# Altium schematic sheet DEVICE.SchDoc
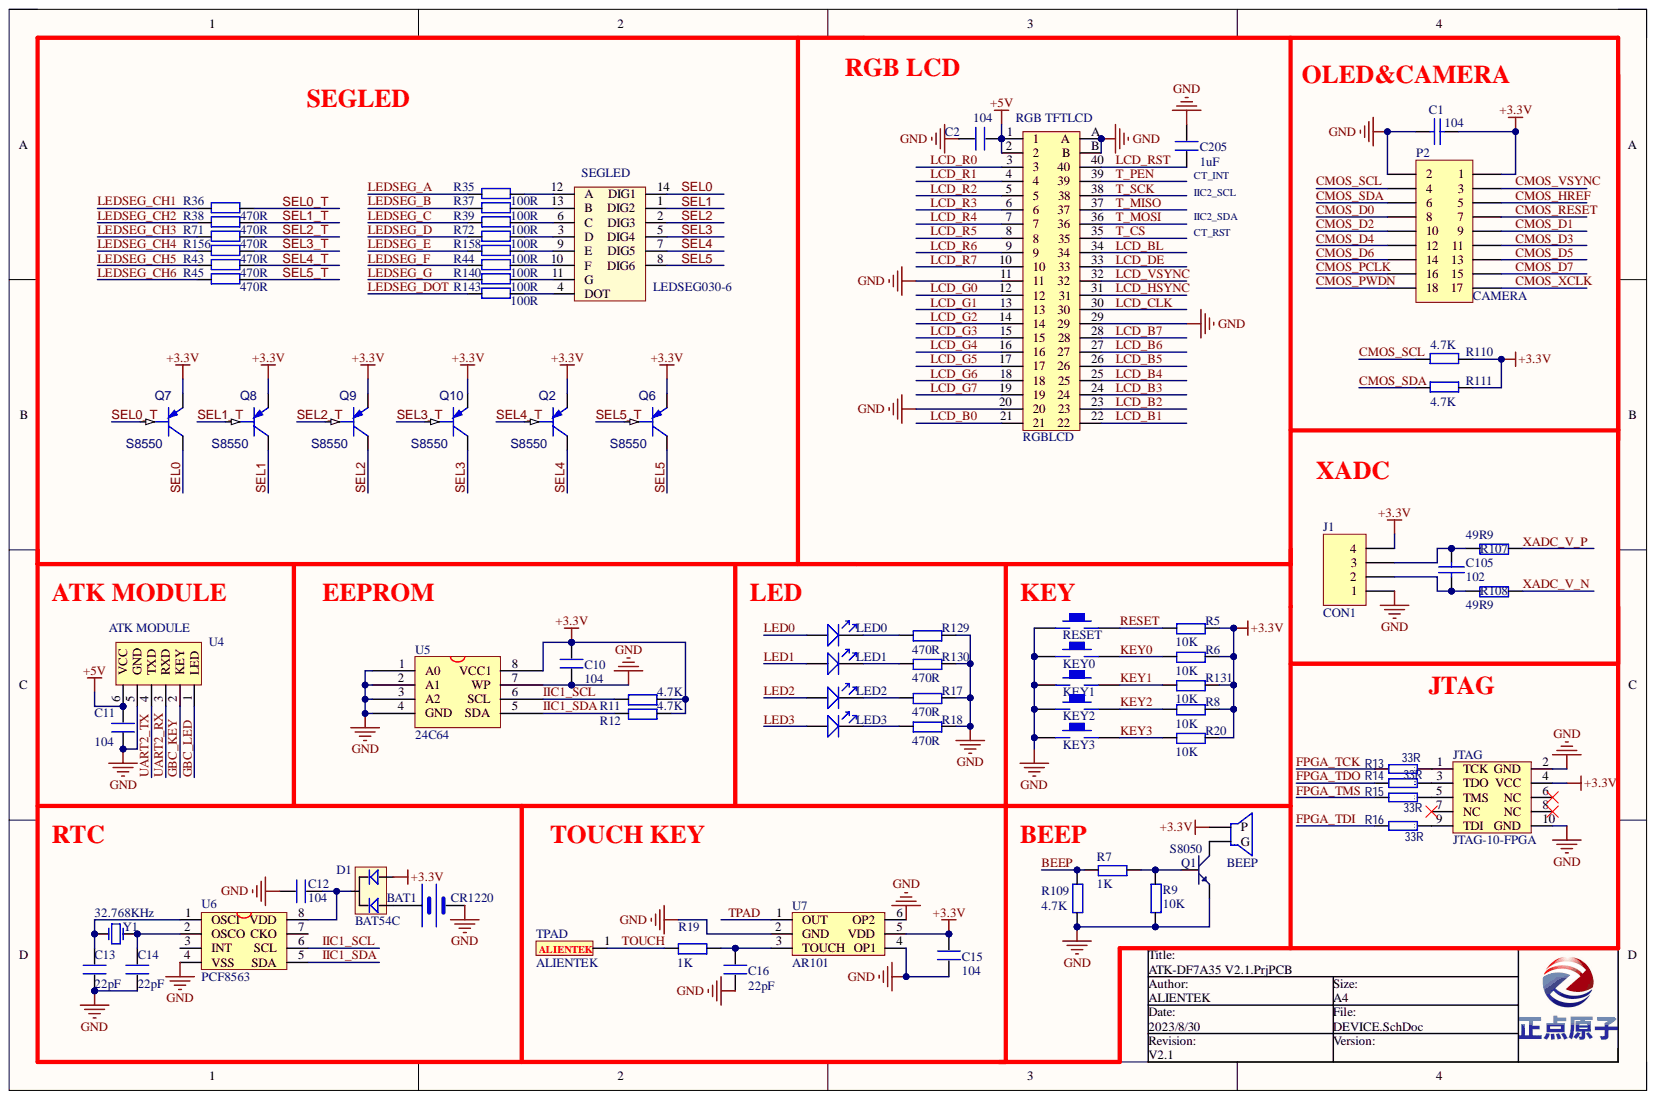
[diagram p0: DEVICE.SchDoc]

## Parts
BAT1  CR1220  [BATM]
BEEP  (no value)  [BELL-5]
C1  104  [0603P]
C2  104  [0603P]
C10  104  [0603P]
C11  104  [0603P]
C12  104  [0603P]
C13  22pF  [0603P]
C14  22pF  [0603P]
C15  104  [0603P]
C16  22pF  [0603P]
C105  102  [0603P]
C205  1uF  [0603P]
D1  BAT54C  [SOT23]
J1  CON1  [HDR1X4]
JTAG  JTAG-10-FPGA  [HDR-IDC-SMD_10PIN-V-ROW2-COL5-PITCH2.00]
KEY0  KEY_M  [KEY_SOP324225]  (value not drawn)
KEY1  KEY_M  [KEY_SOP324225]  (value not drawn)
KEY2  KEY_M  [KEY_SOP324225]  (value not drawn)
KEY3  KEY_M  [KEY_SOP324225]  (value not drawn)
LED0  PS_LED0  [SMDLED-0805]  (value not drawn)
LED1  PS_LED1  [SMDLED-0805]  (value not drawn)
LED2  PS_LED0  [SMDLED-0805]  (value not drawn)
LED3  PS_LED1  [SMDLED-0805]  (value not drawn)
P2  CAMERA  [HDR2X9]
Q1  S8050  [SOT-23R]
Q2  S8550  [SOT23]
Q6  S8550  [SOT23]
Q7  S8550  [SOT23]
Q8  S8550  [SOT23]
Q9  S8550  [SOT23]
Q10  S8550  [SOT23]
R5  10K  [0603P]
R6  10K  [0603P]
R7  1K  [0603P]
R8  10K  [0603P]
R9  10K  [0603P]
R11  4.7K  [0603P]
R12  4.7K  [0603P]
R13  33R  [0603P]
R14  33R  [0603P]
R15  33R  [0603P]
R16  33R  [0603P]
R17  470R  [0603P]
R18  470R  [0603P]
R19  1K  [0603P]
R20  10K  [0603P]
R35  100R  [0603P]
R36  470R  [0603P]
R37  100R  [0603P]
R38  470R  [0603P]
R39  100R  [0603P]
R43  470R  [0603P]
R44  100R  [0603P]
R45  470R  [0603P]
R71  470R  [0603P]
R72  100R  [0603P]
R107  49R9  [0603P]
R108  49R9  [0603P]
R109  4.7K  [0603P]
R110  4.7K  [0603P]
R111  4.7K  [0603P]
R129  470R  [0603P]
R130  470R  [0603P]
R131  10K  [0603P]
R140  100R  [0603P]
R143  100R  [0603P]
R156  470R  [0603P]
R158  100R  [0603P]
RESET  KEY_M  [KEY_SOP324225]  (value not drawn)
RGB_TFTLCD  RGBLCD  [FPC-TOP40]
SEGLED  LEDSEG030-6  [leddisplay-0.3]
TPAD  ALIENTEK  [ALIENTEK_PAD1]
U4  ATK MODULE  [HEAD6]
U5  24C64  [SOP-8]
U6  PCF8563  [SOP-8]
U7  AR101  [SOT-23-6]
Y1  32.768KHz  [XTAL32]

## Nets
+3.3V: BEEP.1 C1.2 C10.2 C15.1 D1.2 J1.4 JTAG.4(VCC) P2.1 Q2.2 Q6.2 Q7.2 Q8.2 Q9.2 Q10.2 R5.2 R6.2 R8.2 R11.2 R12.2 R20.2 R110.1 R111.1 R131.2 U5.8(VCC1) U7.5(VDD)
+5V: C2.2 C11.1 RGB_TFTLCD.1 RGB_TFTLCD.2 U4.6(VCC)
GND: BAT1.2 C1.1 C2.1 C10.1 C11.2 C12.2 C13.2 C14.2 C15.2 C16.1 C205.2 J1.1 JTAG.2(GND) JTAG.10(GND) KEY0.1 KEY1.1 KEY2.1 KEY3.1 P2.2 Q1.1 R9.1 R17.2 R18.2 R109.1 R129.2 R130.2 RESET.1 RGB_TFTLCD.11 RGB_TFTLCD.20 RGB_TFTLCD.29 RGB_TFTLCD.A RGB_TFTLCD.B U4.5(GND) U5.1(A0) U5.2(A1) U5.3(A2) U5.4(GND) U5.7(WP) U6.4(VSS) U7.2(GND) U7.4(OP1) U7.6(OP2)
BEEP: R7.1 R109.2
CMOS_D0: P2.8
CMOS_D1: P2.9
CMOS_D2: P2.10
CMOS_D3: P2.11
CMOS_D4: P2.12
CMOS_D5: P2.13
CMOS_D6: P2.14
CMOS_D7: P2.15
CMOS_HREF: P2.5
CMOS_PCLK: P2.16
CMOS_PWDN: P2.18
CMOS_RESET: P2.7
CMOS_SCL: P2.4 R110.2
CMOS_SDA: P2.6 R111.2
CMOS_VSYNC: P2.3
CMOS_XCLK: P2.17
FPGA_TCK: R13.2
FPGA_TDI: R16.2
FPGA_TDO: R14.2
FPGA_TMS: R15.2
GBC_KEY: U4.2(KEY)
GBC_LED: U4.1(LED)
IIC1_SCL: R11.1 U5.6(SCL) U6.6(SCL)
IIC1_SDA: R12.1 U5.5(SDA) U6.5(SDA)
KEY0: KEY0.2 R6.1
KEY1: KEY1.2 R131.1
KEY2: KEY2.2 R8.1
KEY3: KEY3.2 R20.1
LCD_B0: RGB_TFTLCD.21
LCD_B1: RGB_TFTLCD.22
LCD_B2: RGB_TFTLCD.23
LCD_B3: RGB_TFTLCD.24
LCD_B4: RGB_TFTLCD.25
LCD_B5: RGB_TFTLCD.26
LCD_B6: RGB_TFTLCD.27
LCD_B7: RGB_TFTLCD.28
LCD_BL: RGB_TFTLCD.34
LCD_CLK: RGB_TFTLCD.30
LCD_DE: RGB_TFTLCD.33
LCD_G0: RGB_TFTLCD.12
LCD_G1: RGB_TFTLCD.13
LCD_G2: RGB_TFTLCD.14
LCD_G3: RGB_TFTLCD.15
LCD_G4: RGB_TFTLCD.16
LCD_G5: RGB_TFTLCD.17
LCD_G6: RGB_TFTLCD.18
LCD_G7: RGB_TFTLCD.19
LCD_HSYNC: RGB_TFTLCD.31
LCD_R0: RGB_TFTLCD.3
LCD_R1: RGB_TFTLCD.4
LCD_R2: RGB_TFTLCD.5
LCD_R3: RGB_TFTLCD.6
LCD_R4: RGB_TFTLCD.7
LCD_R5: RGB_TFTLCD.8
LCD_R6: RGB_TFTLCD.9
LCD_R7: RGB_TFTLCD.10
LCD_RST: C205.1 RGB_TFTLCD.40
LCD_VSYNC: RGB_TFTLCD.32
LED0: LED0.1
LED1: LED1.1
LED2: LED2.1
LED3: LED3.1
LEDSEG_A: R35.2
LEDSEG_B: R37.2
LEDSEG_C: R39.2
LEDSEG_CH1: R36.2
LEDSEG_CH2: R38.2
LEDSEG_CH3: R71.2
LEDSEG_CH4: R156.2
LEDSEG_CH5: R43.2
LEDSEG_CH6: R45.2
LEDSEG_D: R72.2
LEDSEG_DOT: R143.2
LEDSEG_E: R158.2
LEDSEG_F: R44.2
LEDSEG_G: R140.2
RESET: R5.1 RESET.2
SEL0: Q7.3 SEGLED.14(DIG1)
SEL0_T: Q7.1 R36.1
SEL1: Q8.3 SEGLED.1(DIG2)
SEL1_T: Q8.1 R38.1
SEL2: Q9.3 SEGLED.2(DIG3)
SEL2_T: Q9.1 R71.1
SEL3: Q10.3 SEGLED.5(DIG4)
SEL3_T: Q10.1 R156.1
SEL4: Q2.3 SEGLED.7(DIG5)
SEL4_T: Q2.1 R43.1
SEL5: Q6.3 SEGLED.8(DIG6)
SEL5_T: Q6.1 R45.1
T_CS: RGB_TFTLCD.35
T_MISO: RGB_TFTLCD.37
T_MOSI: RGB_TFTLCD.36
T_PEN: RGB_TFTLCD.39
T_SCK: RGB_TFTLCD.38
TOUCH: R19.1 TPAD.1
TPAD: U7.1(OUT)
UART2_RX: U4.3(RXD)
UART2_TX: U4.4(TXD)
XADC_V_N: R108.2
XADC_V_P: R107.2
NetBAT1_1: BAT1.1 D1.1
NetBEEP_2: BEEP.2 Q1.3
NetC12_1: C12.1 D1.3 U6.8(VDD)
NetC13_1: C13.1 U6.1(OSCI) Y1.2
NetC14_1: C14.1 U6.2(OSCO) Y1.1
NetC16_2: C16.2 R19.2 U7.3(TOUCH)
NetC105_1: C105.1 J1.2 R108.1
NetC105_2: C105.2 J1.3 R107.1
NetJTAG_1: JTAG.1(TCK) R13.1
NetJTAG_3: JTAG.3(TDO) R14.1
NetJTAG_5: JTAG.5(TMS) R15.1
NetJTAG_9: JTAG.9(TDI) R16.1
NetLED0_2: LED0.2 R129.1
NetLED1_2: LED1.2 R130.1
NetLED2_2: LED2.2 R17.1
NetLED3_2: LED3.2 R18.1
NetQ1_2: Q1.2 R7.2 R9.2
NetR35_1: R35.1 SEGLED.12(A)
NetR37_1: R37.1 SEGLED.13(B)
NetR39_1: R39.1 SEGLED.6(C)
NetR44_1: R44.1 SEGLED.10(F)
NetR72_1: R72.1 SEGLED.3(D)
NetR140_1: R140.1 SEGLED.11(G)
NetR143_1: R143.1 SEGLED.4(DOT)
NetR158_1: R158.1 SEGLED.9(E)

Not connected: JTAG 6(NC) 7(NC) 8(NC); U6 3(INT) 7(CKO)

## Library data of the parts
BAT1 = BAT: Component Kind: Standard; Library Name: ALIENTEK_COMPONENT.IntLib
BEEP = BEEP: Component Kind: Standard; Library Name: ALIENTEK_COMPONENT.IntLib
C1 C105 = CAP_104_0.1uf: Component Kind: Standard; Library Name: ZYNQ.SCHLIB
C2 C10-C16 C205 = C: Component Kind: Standard
  C2 C205: Library Name: ALIENTEK_COMPONENT.IntLib
  C10-C16: Library Name: MYCOMLIB.IntLib
D1 = BAT54C: 双肖特基二极管; Component Kind: Standard; Library Name: ALIENTEK_COMPONENT.IntLib
J1 = Header 5: Header, 4-Pin; Component Kind: Standard; LatestRevisionDate: 17-Jul-2002; LatestRevisionNote: Re-released for DXP Platform.; Library Name: A7_Fun_Library.SchLib; Publisher: Altium Limited
LED0-LED3 = LED: Component Kind: Standard; Library Name: ALIENTEK_COMPONENT.IntLib
P2 = Header 9X2: Header, 9-Pin, Dual row; Component Kind: Standard; LatestRevisionDate: 17-Jul-2002; LatestRevisionNote: Re-released for DXP Platform.; Library Name: Miscellaneous Connectors.IntLib; Publisher: Altium Limited
Q1 = NPN: 8050/BCW846/BCW847; Component Kind: Standard; Library Name: ALIENTEK_COMPONENT.IntLib
Q2 Q6-Q10 = PNP: Component Kind: Standard
R5-R9 R11 R12 R17-R20 R35-R39 R43-R45 R71 R72 R107-R111 R129-R131 R140 R143 R156 R158 = R: Component Kind: Standard
  R5-R9 R11 R12 R17-R20 R107-R111 R129-R131: Library Name: MYCOMLIB.IntLib
  R35-R39 R43-R45 R71 R72 R140 R143 R156 R158: Library Name: ALIENTEK_COMPONENT.IntLib
R13-R16 = RES: Component Kind: Standard
RGB_TFTLCD = FPC-40: FPC-40P-0.5mm; Component Kind: Standard; Library Name: ALIENTEK_COMPONENT.IntLib
SEGLED = LEDSEG030-6: 6位数码管,0.3寸,共阴,41*11*5.8mm; Component Kind: Standard; Library Name: ALIENTEK_COMPONENT.IntLib
U4 = ATK-HC05: Component Kind: Standard; Description: ATK-HC05; Library Name: ALIENTEK_COMPONENT.IntLib
U5 = 24C256: Component Kind: Standard; Library Name: MYCOMLIB.IntLib
U6 = PCF8563: 时钟芯片; Component Kind: Standard; Library Name: ALIENTEK_COMPONENT.IntLib
U7 = AR101: 单路电容触摸芯片 JL223B; Component Kind: Standard; Library Name: ALIENTEK_COMPONENT.IntLib
Y1 = XTAL: 普通晶振; Component Kind: Standard; LatestRevisionDate: 17-Jul-2002; LatestRevisionNote: Re-released for DXP Platform.; Library Name: ALIENTEK_COMPONENT.IntLib; Published: 8-Jun-2000; Publisher: Altium Limited
JTAG = JTAG-10-FPGA: Component Kind: Standard; Library Name: ALIENTEK_COMPONENT.IntLib
KEY0-KEY3 RESET = KEY_M: Component Kind: Standard; Library Name: ALIENTEK_COMPONENT.IntLib
TPAD = ALIENTEK: Component Kind: Standard; Library Name: WARSHIP_STM32_V4.3.SCHLIB
